# Altium schematic sheet Sheet2.SchDoc(Sheet2)
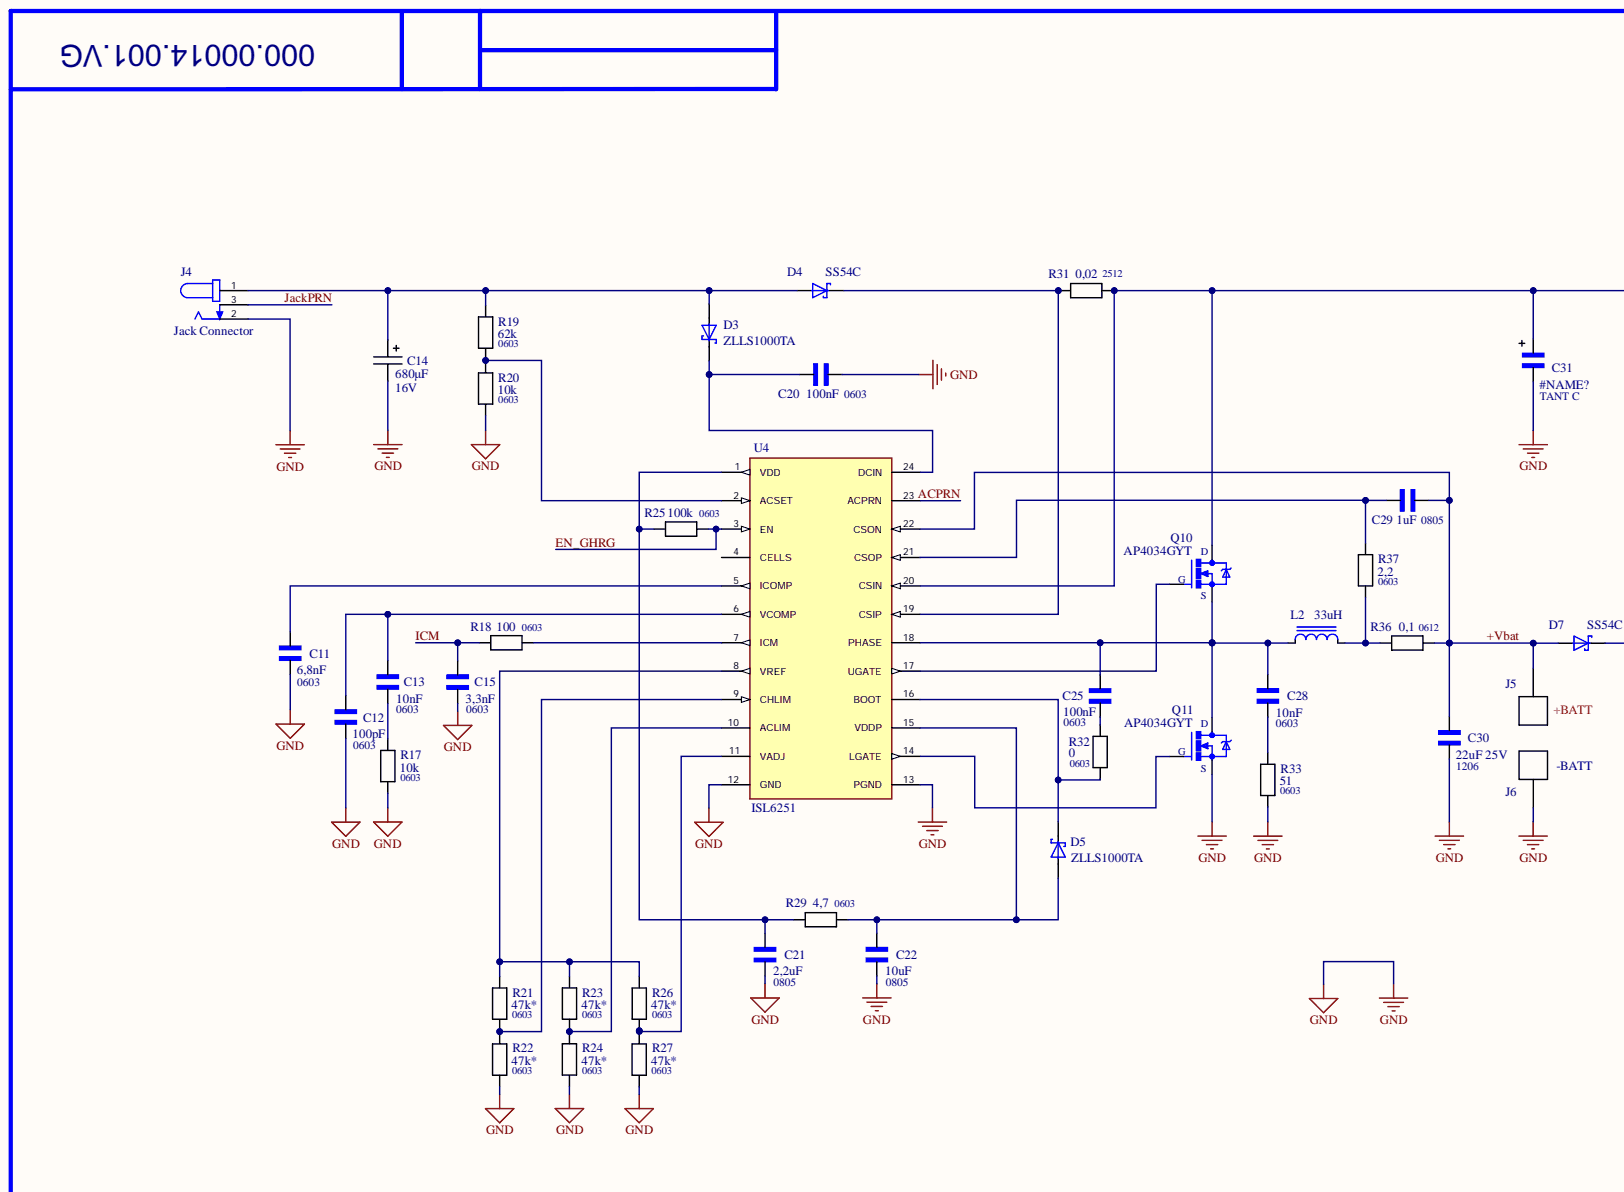
[diagram p0: Sheet2.SchDoc, top left]
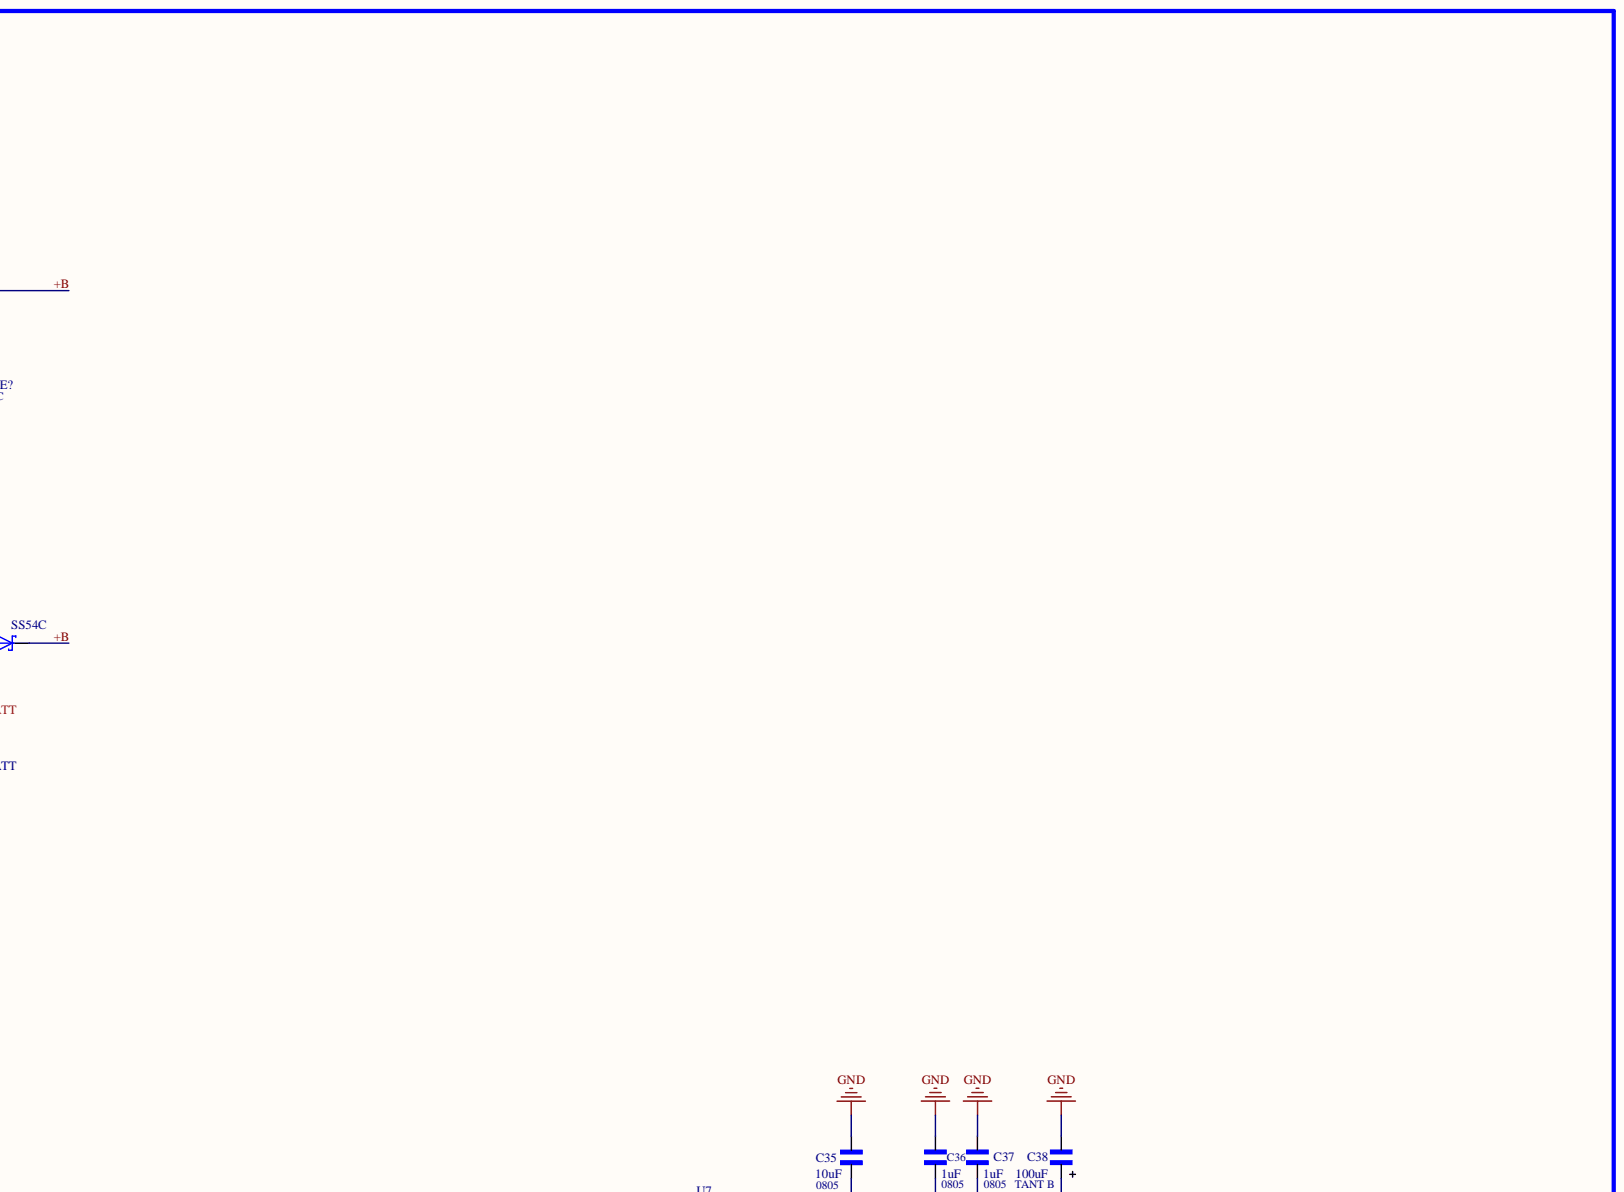
[diagram p1: Sheet2.SchDoc, top right]
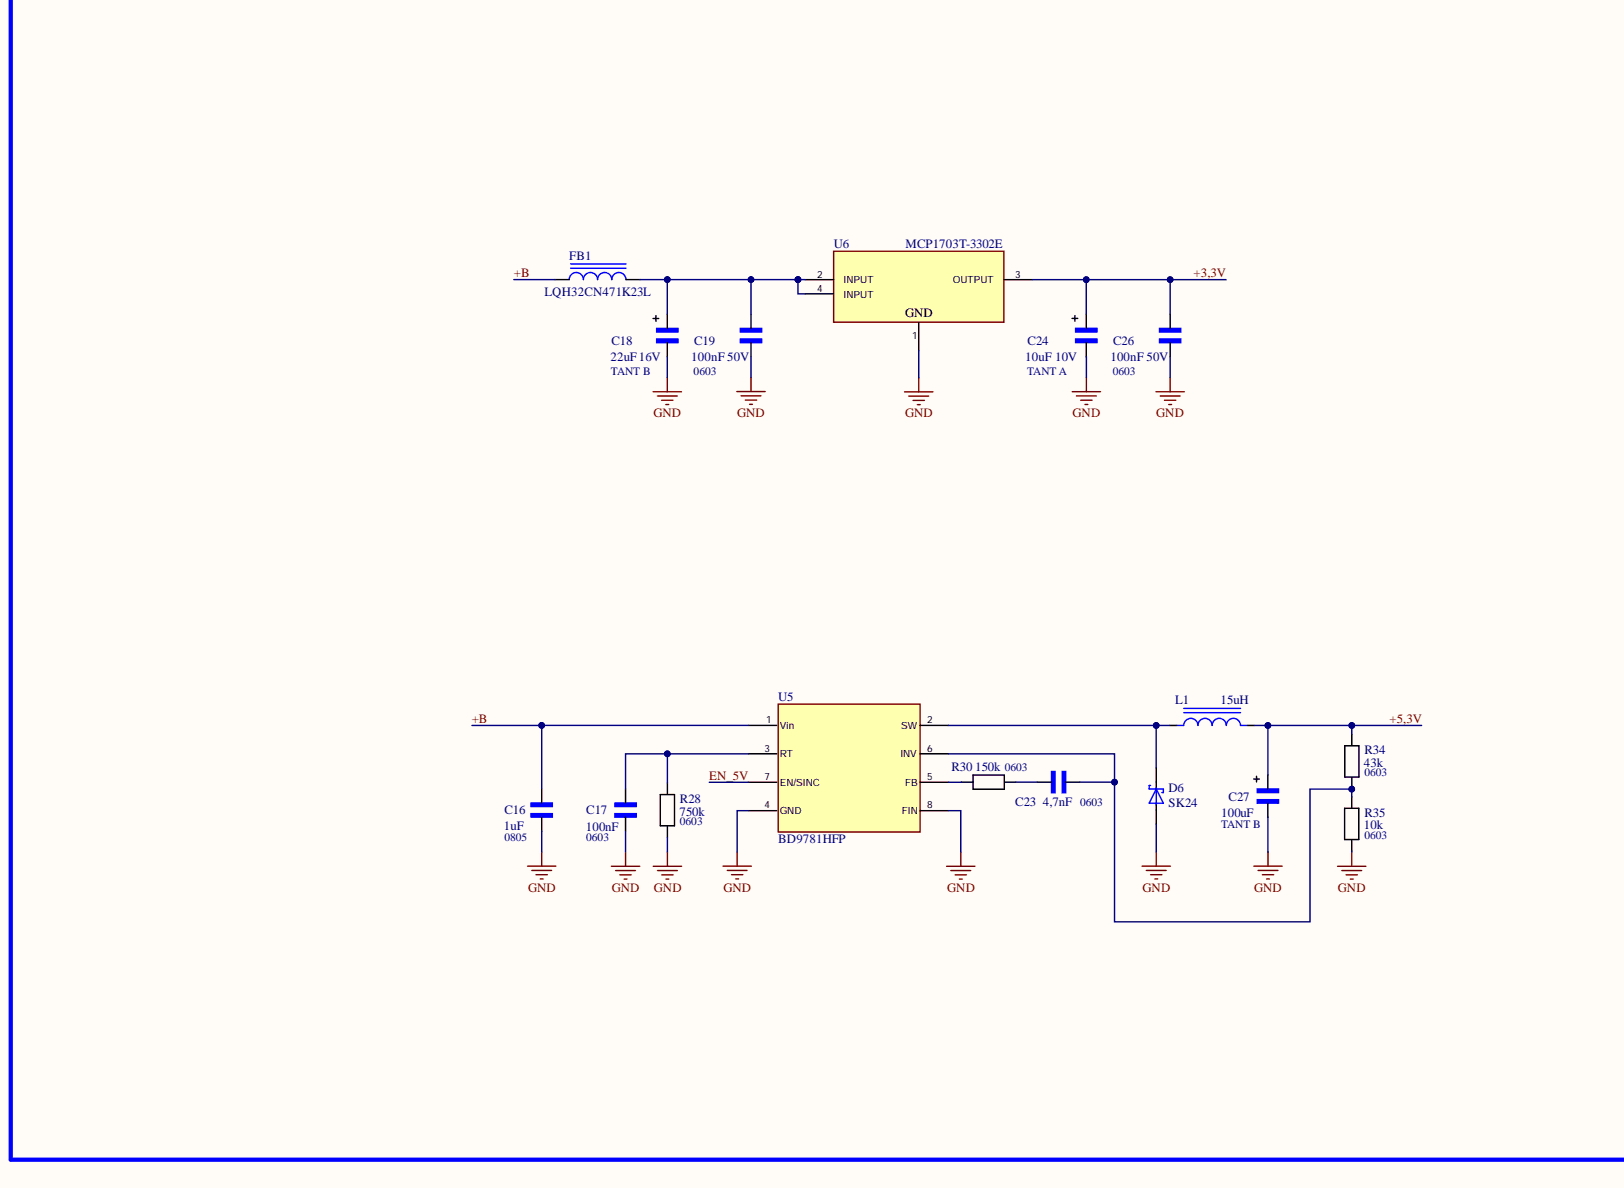
[diagram p2: Sheet2.SchDoc, bottom left]
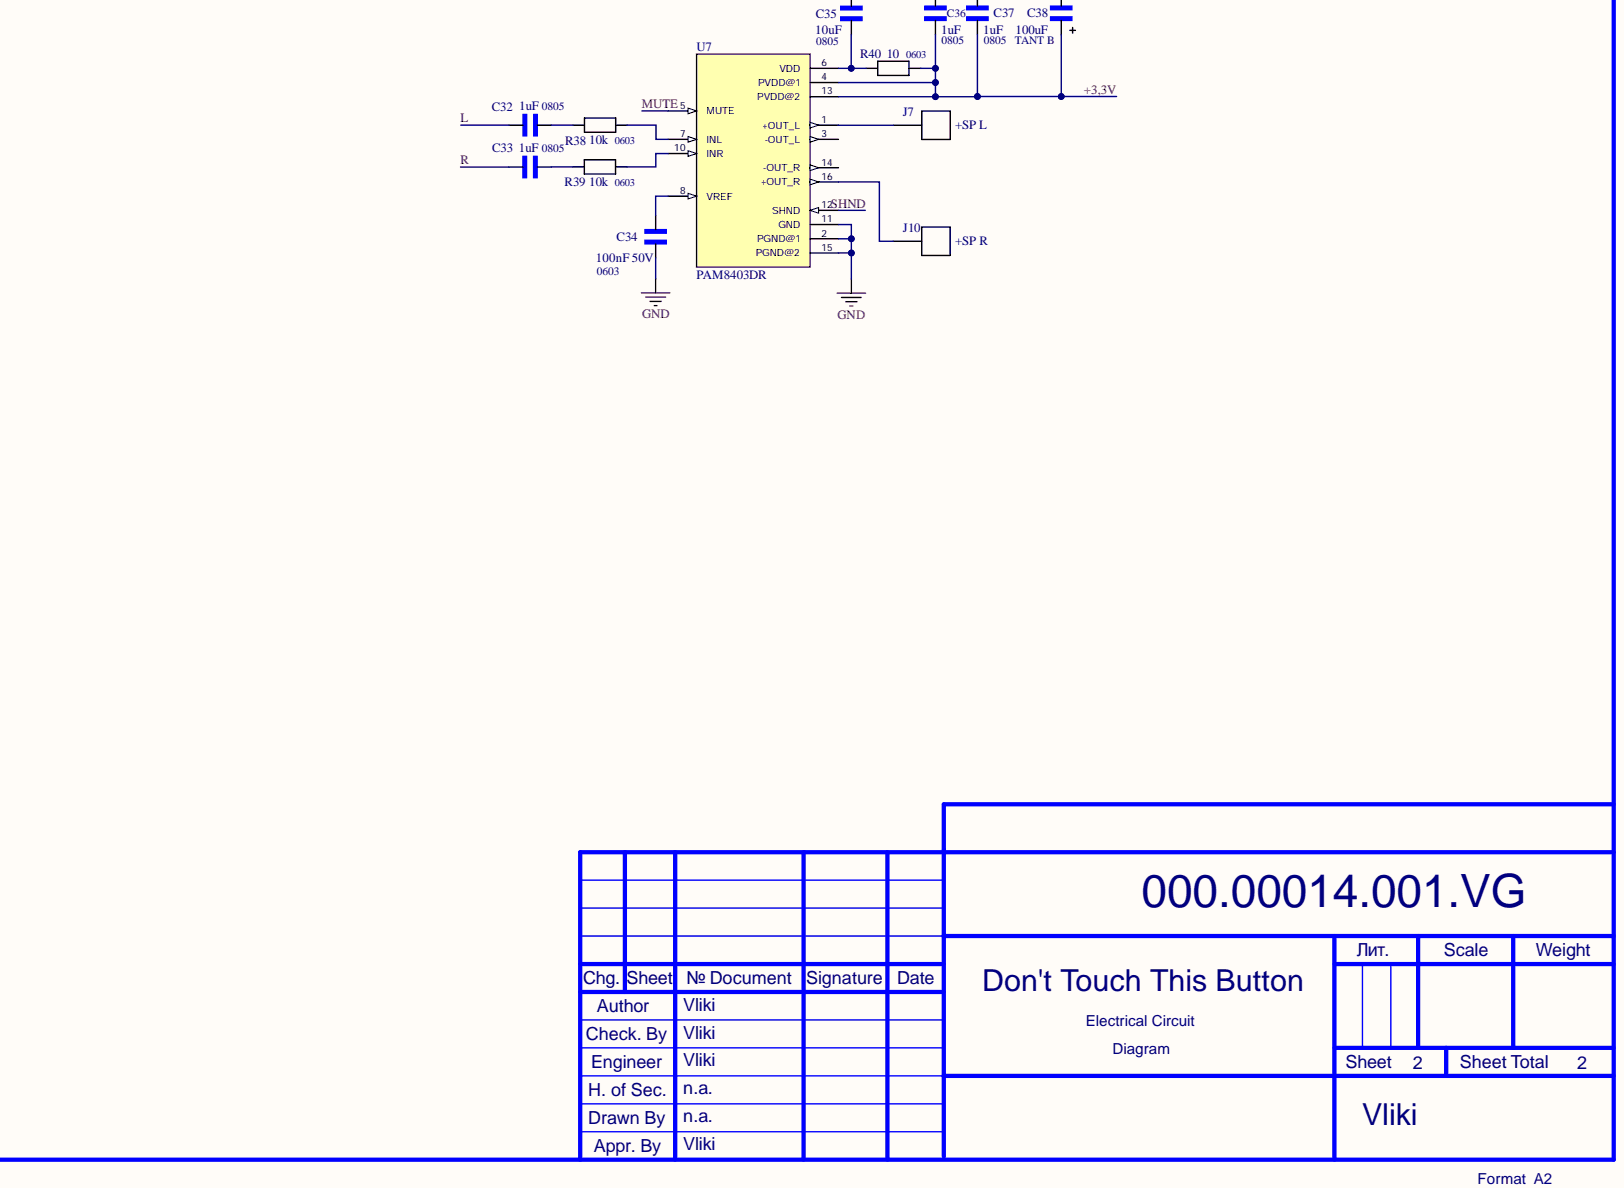
[diagram p3: Sheet2.SchDoc, bottom right]

## Parts
C11  6,8nF  Case: 0603  [SMD 0603 CAP]
C12  100pF  Case: 0603  [SMD 0603 CAP]
C13  10nF  Case: 0603  [SMD 0603 CAP]
C14  680μF  Voltage: 16V  [CAP 8x16]
C15  3,3nF  Case: 0603  [SMD 0603 CAP]
C16  1uF  Case: 0805  [SMD 0805 CAP]
C17  100nF  Case: 0603  [SMD 0603 CAP]
C18  22uF  Case: TANT B  Voltage: 16V  [SMD 1210 CAP TANT YelloW]
C19  100nF 50V  Case: 0603  [SMD 0603 CAP]
C20  100nF  Case: 0603  [SMD 0603 CAP]
C21  2,2uF  Case: 0805  [SMD 0805 CAP]
C22  10uF  Case: 0805  [SMD 0805 CAP]
C23  4,7nF  Case: 0603  [SMD 0603 CAP]
C24  10uF  Voltage: 10V  [SMD 1206 CAP TANT Yellow]
C25  100nF  Case: 0603  [SMD 0603 CAP]
C26  100nF 50V  Case: 0603  [SMD 0603 CAP]
C27  100uF  Case: TANT B  [SMD 1210 CAP TANT Yellow]
C28  10nF  Case: 0603  [SMD 0603 CAP]
C29  1uF  Case: 0805  [SMD 0805 CAP]
C30  22uF 25V  Case: 1206  [SMD 1206 CAP]
C31  #NAME?  Case: TANT C  [SMD 2312 CAP TANT Yellow]
C32  1uF  Case: 0805  [SMD 0805 CAP]
C33  1uF  Case: 0805  [SMD 0805 CAP]
C34  100nF 50V  Case: 0603  [SMD 0603 CAP]
C35  10uF  Case: 0805  [SMD 0805 CAP]
C36  1uF  Case: 0805  [SMD 0805 CAP]
C37  1uF  Case: 0805  [SMD 0805 CAP]
C38  100uF  Case: TANT B  [SMD 1210 CAP TANT Yellow]
D3  ZLLS1000TA  [SOT-23-3]
D4  SS54C  [SMC]
D5  ZLLS1000TA  [SOT-23-3]
D6  SK24  [SMA DO-214]
D7  SS54C  [SMC]
FB1  LQH32CN471K23L  [SMD 1210 LQH]
J4  Jack Connector  [Connector jack 2.1]
J5  +BATT  [Wire-2]
J6  -BATT  [Wire-2]
J7  +SP L  [Wire-2]
J10  +SP R  [Wire-2]
L1  15uH  [DRH104R]
L2  33uH  [SPI0705 (SD75)]
Q10  AP4034GYT  [PMPAK 3x3]
Q11  AP4034GYT  [PMPAK 3x3]
R17  10k  Case: 0603  [SMD 0603]
R18  100  Case: 0603  [SMD 0603]
R19  62k  Case: 0603  [SMD 0603]
R20  10k  Case: 0603  [SMD 0603]
R21  47k*  Case: 0603  [SMD 0603]
R22  47k*  Case: 0603  [SMD 0603]
R23  47k*  Case: 0603  [SMD 0603]
R24  47k*  Case: 0603  [SMD 0603]
R25  100k  Case: 0603  [SMD 0603]
R26  47k*  Case: 0603  [SMD 0603]
R27  47k*  Case: 0603  [SMD 0603]
R28  750k  Case: 0603  [SMD 0603]
R29  4,7  Case: 0603  [SMD 0603]
R30  150k  Case: 0603  [SMD 0603]
R31  0,02  Case: 2512  [SMD 2512 RES]
R32  0  Case: 0603  [SMD 0603]
R33  51  Case: 0603  [SMD 0603]
R34  43k  Case: 0603  [SMD 0603]
R35  10k  Case: 0603  [SMD 0603]
R36  0,1  Case: 0612  [SMD 0612 RES]
R37  2,2  Case: 0603  [SMD 0603]
R38  10k  Case: 0603  [SMD 0603]
R39  10k  Case: 0603  [SMD 0603]
R40  10  Case: 0603  [SMD 0603]
U4  ISL6251  [QSOP-24]
U5  BD9781HFP  [HRP-7]
U6  MCP1703T-3302E  [SOT-89(MB)]
U7  PAM8403DR  [SOP-16]

## Nets
GND: C11.1 C12.1 C14.2 C15.1 C16.1 C17.1 C18.2 C19.1 C20.1 C21.1 C22.1 C24.2 C26.1 C27.2 C30.2 C31.2 C34.1 C35.2 C36.1 C37.1 C38.2 D6.A J4.2 J6.1 Q11.2 R17.1 R20.1 R22.1 R24.1 R27.1 R28.1 R33.1 R35.1 U4.12(GND) U4.13(PGND) U5.4(GND) U5.8(FIN) U6.1 U7.2(PGND@1) U7.11(GND) U7.15(PGND@2)
+3,3V: C24.1 C26.2 C36.2 C37.2 C38.1 R40.1 U6.3(OUTPUT) U7.4(PVDD@1) U7.13(PVDD@2)
+5,3V: C27.1 L1.2 R34.2
+B: C16.2 C31.1 D7.C FB1.1 Q10.3 R31.1 U4.20(CSIN) U5.1(Vin)
+Vbat: C29.1 C30.1 D7.A J5.1 R36.1 U4.22(CSON)
ACPRN: U4.23(ACPRN)
EN_5V: U5.7(EN/SINC)
EN_GHRG: R25.1 U4.3(EN)
ICM: C15.2 R18.2
JackPRN: J4.3
L: C32.1
MUTE: U7.5(MUTE)
R: C33.1
SHND: U7.12(SHND)
NetC11_2: C11.2 U4.5(ICOMP)
NetC12_2: C12.2 C13.2 U4.6(VCOMP)
NetC13_1: C13.1 R17.2
NetC14_1: C14.1 D3.3 D4.A J4.1 R19.2
NetC17_2: C17.2 R28.2 U5.3(RT)
NetC18_1: C18.1 C19.2 FB1.2 U6.2(INPUT) U6.4(INPUT)
NetC20_2: C20.2 D3.1 U4.24(DCIN)
NetC21_2: C21.2 R25.2 R29.2 U4.1(VDD)
NetC22_2: C22.2 D5.3 R29.1 U4.15(VDDP)
NetC23_1: C23.1 R34.1 R35.2 U5.6(INV)
NetC23_2: C23.2 R30.1
NetC25_1: C25.1 R32.2
NetC25_2: C25.2 C28.2 L2.1 Q10.2 Q11.3 U4.18(PHASE)
NetC28_1: C28.1 R33.2
NetC29_2: C29.2 R37.2 U4.21(CSOP)
NetC32_2: C32.2 R38.2
NetC33_2: C33.2 R39.2
NetC34_2: C34.2 U7.8(VREF)
NetC35_1: C35.1 R40.2 U7.6(VDD)
NetD4_C: D4.C R31.2 U4.19(CSIP)
NetD5_1: D5.1 R32.1 U4.16(BOOT)
NetD6_C: D6.C L1.1 U5.2(SW)
NetJ7_1: J7.1 U7.1(+OUT_L)
NetJ10_1: J10.1 U7.16(+OUT_R)
NetL2_2: L2.2 R36.2 R37.1
NetQ10_1: Q10.1 U4.17(UGATE)
NetQ11_1: Q11.1 U4.14(LGATE)
NetR18_1: R18.1 U4.7(ICM)
NetR19_1: R19.1 R20.2 U4.2(ACSET)
NetR21_1: R21.1 R22.2 U4.9(CHLIM)
NetR21_2: R21.2 R23.2 R26.2 U4.8(VREF)
NetR23_1: R23.1 R24.2 U4.10(ACLIM)
NetR26_1: R26.1 R27.2 U4.11(VADJ)
NetR30_2: R30.2 U5.5(FB)
NetR38_1: R38.1 U7.7(INL)
NetR39_1: R39.1 U7.10(INR)

Not connected: U4 4(CELLS); U7 3(-OUT_L) 14(-OUT_R)

## Library data of the parts
C11 = CL32B106KLJNNNE: 6.8nF 50V X7R +-10%; Value: 6,8nF 50V; Component Kind: Standard; HelpURL: https://store.comet.bg/Catalogue/Product/4273/; Library Name: CAN_Library.IntLib
C12 = CL32B106KLJNNNE: 150pF 50V C0G +-5%; Manufacturer: SAMSUNG ELECTRO-MECHANICS; Model: CL10C151JB8NNNC; Value: 100pF 50V; Component Kind: Standard; HelpURL: https://store.comet.bg/Catalogue/Product/7008/; Library Name: CAN_Library.IntLib
C13 C28 = CL32B106KLJNNNE: 10nF 50V X7R +-10%; Manufacturer: SAMSUNG ELECTRO-MECHANICS; Model: CL10B103KB8NNNC; Component Kind: Standard; HelpURL: https://store.comet.bg/Catalogue/Product/6086/; Library Name: CAN_Library.IntLib; Value: 10nF 50V
C14 = CAP Aluminium: Electrolytic capacitor, TM, 20%,-40~105°C, 8x16mm RM3.5, 0.26Ohm; Manufacturer: FUJICON; Tipe: Wide Temperature; Case: 8x16x3,5; Component Kind: Standard; HelpURL: https://store.comet.bg/Catalogue/Product/44662/; Library Name: CAN_Library.IntLib; Temperature: -40...+105°C; Value: 680μF
C15 = CL32B106KLJNNNE: 2.2uF 16V X7R +-10%; Manufacturer: SAMSUNG ELECTRO-MECHANICS; Model: CL10B332KB8NNNC; Value: 3,3nF 50V; Component Kind: Standard; HelpURL: https://store.comet.bg/Catalogue/Product/5613/; Library Name: CAN_Library.IntLib
C16 C29 C32 C33 C36 C37 = CL32B106KLJNNNE: 1.0uF 50V X7R +-10%; Manufacturer: SAMSUNG ELECTRO-MECHANICS; Model: CL21B105KBFNNNE; Value: 1uF 50V; Component Kind: Standard; HelpURL: https://store.comet.bg/Catalogue/Product/9031/; Library Name: CAN_Library.IntLib
C17 C20 C25 = CL32B106KLJNNNE: 100nF 50V X7R +-10%; Manufacturer: SAMSUNG ELECTRO-MECHANICS; Model: CL10B104KB8NNNC; Component Kind: Standard; HelpURL: https://store.comet.bg/Catalogue/Product/5963/; Library Name: CAN_Library.IntLib; Value: 100nF 50V
C18 = Cap Tant 220uF 10V Case E: TANT B SMD 22uF 16V 293D226X9016B2TE3; Manufacturer: VISHAY; Component Kind: Standard; HalpURL: https://store.comet.bg/Catalogue/Product/49334/; Library Name: CAN_Library.IntLib; Value: 22uF
C19 C26 C34 = CL32B106KLJNNNE: 100nF 50V X7R +-10%; Manufacturer: SAMSUNG ELECTRO-MECHANICS; Component Kind: Standard; HelpURL: https://store.comet.bg/Catalogue/Product/36844/; Library Name: CAN_Library.IntLib; Model: CL32B106KLJNNNE; Value: 100nF 50V
C21 = CL32B106KLJNNNE: 2.2uF 16V X7R +-10%; Manufacturer: SAMSUNG ELECTRO-MECHANICS; Model: CL21B225KOFNNNE; Value: 2,2uF 16V; Component Kind: Standard; HelpURL: https://store.comet.bg/Catalogue/Product/9125/; Library Name: CAN_Library.IntLib
C22 C35 = CL32B106KLJNNNE: 10uF 6.3V X7R +-10%; Manufacturer: SAMSUNG ELECTRO-MECHANICS; Model: CL21B106KQQNNNE; Value: 10uF 6,3V; Component Kind: Standard; HelpURL: https://store.comet.bg/Catalogue/Product/9623/; Library Name: CAN_Library.IntLib
C23 = CL32B106KLJNNNE: 4.7nF 50V X7R +-10%; Manufacturer: SAMSUNG ELECTRO-MECHANICS; Model: CL10B472KB8NNNC; Value: 4,7nF 50V; Component Kind: Standard; HelpURL: https://store.comet.bg/Catalogue/Product/6165/; Library Name: CAN_Library.IntLib
C24 = Cap Tant 220uF 10V Case E: TANT A SMD 10uF 10V TAJA106K010RNJ; Manufacturer: VISHAY; Case: TANT A; Component Kind: Standard; HalpURL: https://store.comet.bg/Catalogue/Product/15617/; Library Name: CAN_Library.IntLib; Value: 10uF
C27 C38 = Cap Tant 220uF 10V Case E: 100uF 6.3V B; Manufacturer: AVX; Voltage: 6,3V; Component Kind: Standard; HalpURL: https://store.comet.bg/Catalogue/Product/8636/; Library Name: CAN_Library.IntLib; Value: 100uF
C30 = CL32B106KLJNNNE: 22uF 25V X5R +-10%; Manufacturer: SAMSUNG ELECTRO-MECHANICS; Model: CL31A226KAHNNNE; Component Kind: Standard; HelpURL: https://store.comet.bg/Catalogue/Product/18151/; Library Name: CAN_Library.IntLib; Value: 22uF 25V
C31 = Cap Tant 220uF 10V Case E: 100uF 16V C 20%; Manufacturer: AVX; Component Kind: Standard; HalpURL: https://store.comet.bg/Catalogue/Product/36992/; Library Name: CAN_Library.IntLib; Value: 100uF; Voltage: 16V
D3 D5 = ZLLS1000TA: Schottky Diode, Vr=40V, If=1.16A, Uf=0.43V/1.0A, Ifsm=22A/<100us, Ir=5uA typ, trr=5.0ns typ; Manufacturer: DIODES/ZETEX; Case: SOT23; Component Kind: Standard; HelpURL: https://store.comet.bg/Catalogue/Product/5164587/; Library Name: CAN_Library.IntLib; Value: ZLLS1000TA
D4 D7 = SS54C: Diode Schottky 40V 5A UFM0.55/5A IFM175A IR0.5mA; Manufacturer: YANGJIE ELECTRONIC; Case: SMC; Component Kind: Standard; HelpURL: https://store.comet.bg/Catalogue/Product/8778/; Library Name: CAN_Library.IntLib; Value: SS54C
D6 = SK24: Diode 40V 2A UFM0.5V/2A IFM 50A IR 0.4mA, Schottky; MF: SM TECHNOLOGY; Case: SMB; Component Kind: Standard; Library Name: CAN_Library.IntLib; URL: https://store.comet.bg/Catalogue/Product/48957/; Value: SK24
FB1 = LQH32CN471K23L: 470uH 19Ohm 60mA 10%    47uH 1.3Ohm 170mA 10%; MF: MURATA ELECTRONICS; Component Kind: Standard; Library Name: CAN_Library.IntLib; MP: LQH32CN471K; Package: 1210; URL 47uH: https://store.comet.bg/Catalogue/Product/48001/; URL 470uH: https://store.comet.bg/Catalogue/Product/48004/; Value: LQH32CN471K23L
J4 = Connector Power Jack: 2.0 x 6.5 mm, 5.0 A, Horizontal, Through Hole, Dc Power Jack Connector; Case: PCB TH; Component Kind: Standard; Library Name: CAN_Library.IntLib; URL: https://store.comet.bg/Catalogue/Product/29148/; Value: Jack Connector
J5-J7 J10 = Wire: Case: 1mm; Component Kind: Standard; Description: Wire; Library Name: CAN_Library.IntLib; Value: Wire
L1 = DRH104R: дросел 15uH 0.05 ohm 3.6A 20%; MF: CORE MASTER; Value: CL15uH SDI104R; Case: DRH104R; Component Kind: Standard; Library Name: CAN_Library.IntLib; URL: https://store.comet.bg/Catalogue/Product/44067/
L2 = CL33uH SRI1205: дросел 33uH 96 mOhm 1.09A 20%; Manufacturer: CORE MASTER; Model: SPI0705 - 330M; Value: CL33uH SPI0705 (SD75); Case: SPI0705 (SD75); Component Kind: Standard; HelpURL: https://store.comet.bg/Catalogue/Product/9515/; Library Name: CAN_Library.IntLib
Q10 Q11 = AP4034GYT: NMOS 30V 15.5A 3.57W 9mOhm/10V; 13.5mOhm/4.5V; MF: ADVANCED POWER ELECTR. CO; MP: AP4034GYT-HF-3TR; Case: PMPAK 3x3; Component Kind: Standard; Library Name: CAN_Library.IntLib; URL: https://store.comet.bg/Catalogue/Product/18305/; Value: AP4034GYT
R17 R22 R24 R27 R35 = Resistor_2: RES SMD 0603 5% 200ppm 47K 1/4W; Component Kind: Standard; Library Name: CAN_Library.IntLib
  R17 R35: HelpURL: https://store.comet.bg/Catalogue/Product/29639/; Value: 10k
  R22 R24 R27: HelpURL: https://store.comet.bg/Catalogue/Product/3476/; Value: 47k*
R18 = Resistor_2: RES SMD 0603 5% 200ppm 100R 1/10W; Component Kind: Standard; HelpURL: https://store.comet.bg/Catalogue/Product/3448/; Library Name: CAN_Library.IntLib; Value: 100
R19 = Resistor_2: RES SMD 0603 5% 200ppm 62K 1/10W; Component Kind: Standard; HelpURL: https://store.comet.bg/Catalogue/Product/4842/; Library Name: CAN_Library.IntLib; Value: 62k
R20 R38 R39 = Resistor_2: RES SMD 0603 5% 200ppm 10K 1/4W; Component Kind: Standard; HelpURL: https://store.comet.bg/Catalogue/Product/29639/; Library Name: CAN_Library.IntLib; Value: 10k
R21 R23 R26 = Resistor_2: RES SMD 0603 5% 200ppm 47K 1/10W; Component Kind: Standard; HelpURL: https://store.comet.bg/Catalogue/Product/3476/; Library Name: CAN_Library.IntLib; Value: 47k*
R25 = Resistor_2: RES SMD 0603 5% 200ppm 100K 1/10W; Component Kind: Standard; HelpURL: https://store.comet.bg/Catalogue/Product/4210/; Library Name: CAN_Library.IntLib; Value: 100k
R28 = Resistor_2: RES SMD 0603 5% 200ppm 750K 1/10W; Component Kind: Standard; HelpURL: https://store.comet.bg/Catalogue/Product/4852/; Library Name: CAN_Library.IntLib; Value: 750k
R29 = Resistor_2: RES SMD 0603 5% 400ppm 4.7R 1/10W; Component Kind: Standard; HelpURL: https://store.comet.bg/Catalogue/Product/5272/; Library Name: CAN_Library.IntLib; Value: 4,7
R30 = Resistor_2: RES SMD 0603 5% 200ppm 150K 1/10W; Component Kind: Standard; HelpURL: https://store.comet.bg/Catalogue/Product/5287/; Library Name: CAN_Library.IntLib; Value: 150k
R31 = Resistor_2: RES SMD 2512 1% 0.02R 2W; Component Kind: Standard; HelpURL: https://store.comet.bg/Catalogue/Product/35370/; Library Name: CAN_Library.IntLib; Value: 0,02
R32 = Resistor_2: RES SMD 0603 JUMPER MAX 50mOhm; Component Kind: Standard; HelpURL: https://store.comet.bg/Catalogue/Product/4437/; Library Name: CAN_Library.IntLib; Value: 0
R33 = Resistor_2: RES SMD 0603 5% 200ppm 51R 1/10W; Component Kind: Standard; HelpURL: https://store.comet.bg/Catalogue/Product/4812/; Library Name: CAN_Library.IntLib; Value: 51
R34 = Resistor_2: RES SMD 0603 5% 200ppm 43K 1/10W; Component Kind: Standard; HelpURL: https://store.comet.bg/Catalogue/Product/4841/; Library Name: CAN_Library.IntLib; Value: 43k
R36 = Resistor_2: RES SMD current sense Chip Resistor, thin film element and long side terminals(0612), 0.1R, 1W, 1%, 50ppm; Component Kind: Standard; HelpURL: https://store.comet.bg/Catalogue/Product/287659/; Library Name: CAN_Library.IntLib; Value: 0,1
R37 = Resistor_2: RES SMD 0603 5% 400ppm 2.2R 1/10W; Component Kind: Standard; HelpURL: https://store.comet.bg/Catalogue/Product/5271/; Library Name: CAN_Library.IntLib; Value: 2,2
R40 = Resistor_2: RES SMD 0603 5% 400ppm 10R 1/10W; Component Kind: Standard; HelpURL: https://store.comet.bg/Catalogue/Product/3435/; Library Name: CAN_Library.IntLib; Value: 10
U4 = ISL6251: Battery Charger Controller; MF: Renesas; Case: QSOP-24; Component Kind: Standard; Library Name: CAN_Library.IntLib; Value: ISL6251
U5 = BD9781HFP: ADJ Vout: 1.0V to Vin / Iout 4A; Vin: 7-35V; 50-500kHz; -40~+125°C; MF: ROHM; Case: HRP-7; Component Kind: Standard; Library Name: CAN_Library.IntLib; URL: https://store.comet.bg/Catalogue/Product/6569/; Value: BD9781HFP
U6 = MCP1703T-3302E/MB: 3.3V±2% 250mA, LDO 0.725V, Vinmax=16V, linear voltage regulator; MF: Microchip; Case: SOT-89; Component Kind: Standard; Library Name: CAN_Library.IntLib; Value: MCP1703T-3302E
U7 = PAM8403DR: Amplifier IC 2-Channel _Stereo_ Class D 16-SOP; Case: SOP-16; Component Kind: Standard; Library Name: CAN_Library.IntLib; Value: PAM8403DR
